# Altium schematic sheet Proximidad.SchDoc(Proximidad)
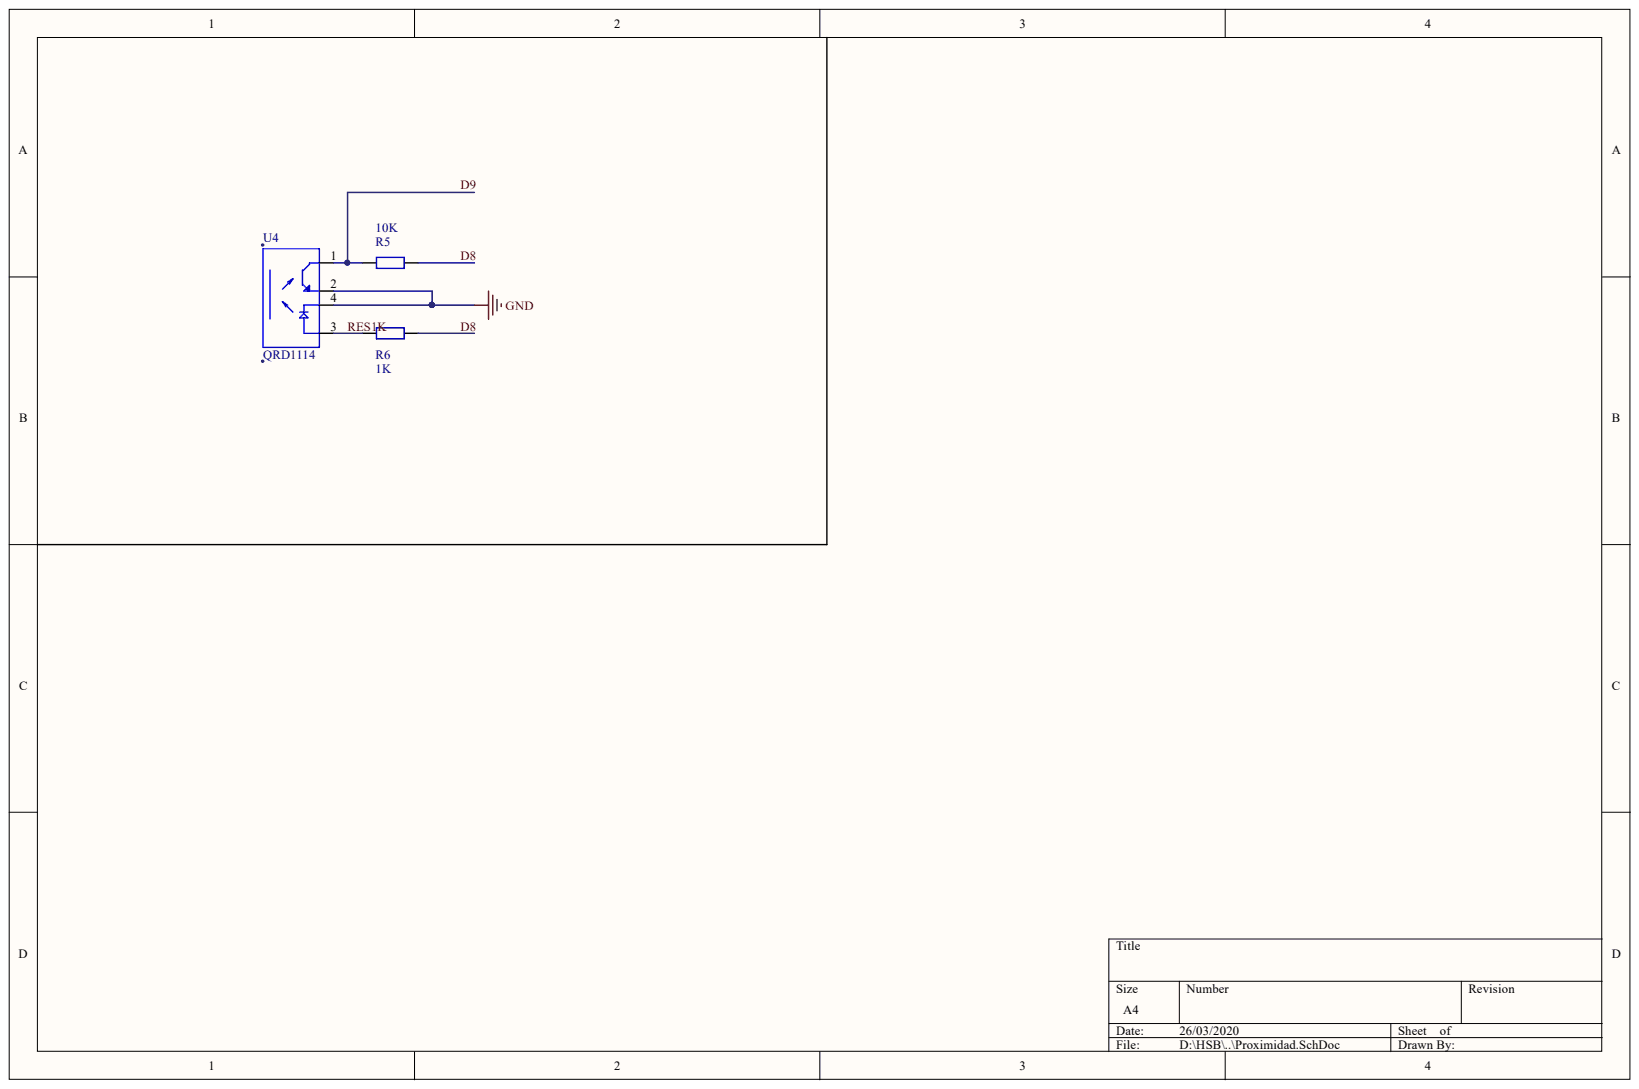
[diagram p0: Proximidad.SchDoc]

## Parts
R5  R  value 10K  [R1206-0.55]
R6  R  value 1K  [R1206-0.55]
U4  QRD1114  [*ONS_QRD1114]

## Nets
GND: U4.2 U4.4
RES1K: R6.1 U4.3
VALUEPROX: R5.1 U4.1
VCC-PROX: R5.2 R6.2

## Library data of the parts
R5 R6 = R: Resistor, generic; Component Kind: Standard; Library Name: IFS_Altium.IntLib
U4 = QRD1114: Sensor Ã³ptico reflectante 0.050 _1.27 mm_ radial - 4 conductores; MF: ON Semiconductor; Component Kind: Standard; Digi-Key_Part_Number: QRD1114-ND; Digi-Key_Purchase_URL: https://www.digikey.com/product-detail/en/on-semiconductor/QRD1114/QRD1114-ND/187536?utm_source=snapeda&utm_medium=aggregator&utm_campaign=symbol; Library Name: QRD1114.SchLib; MP: QRD1114; Package: Radial-4 ON Semiconductor; SnapEDA_Link: https://www.snapeda.com/parts/QRD1114/ON%20Semiconductor/view-part/2706462/?ref=snap
